# Altium schematic sheet Accessories.SchDoc(Accessories)
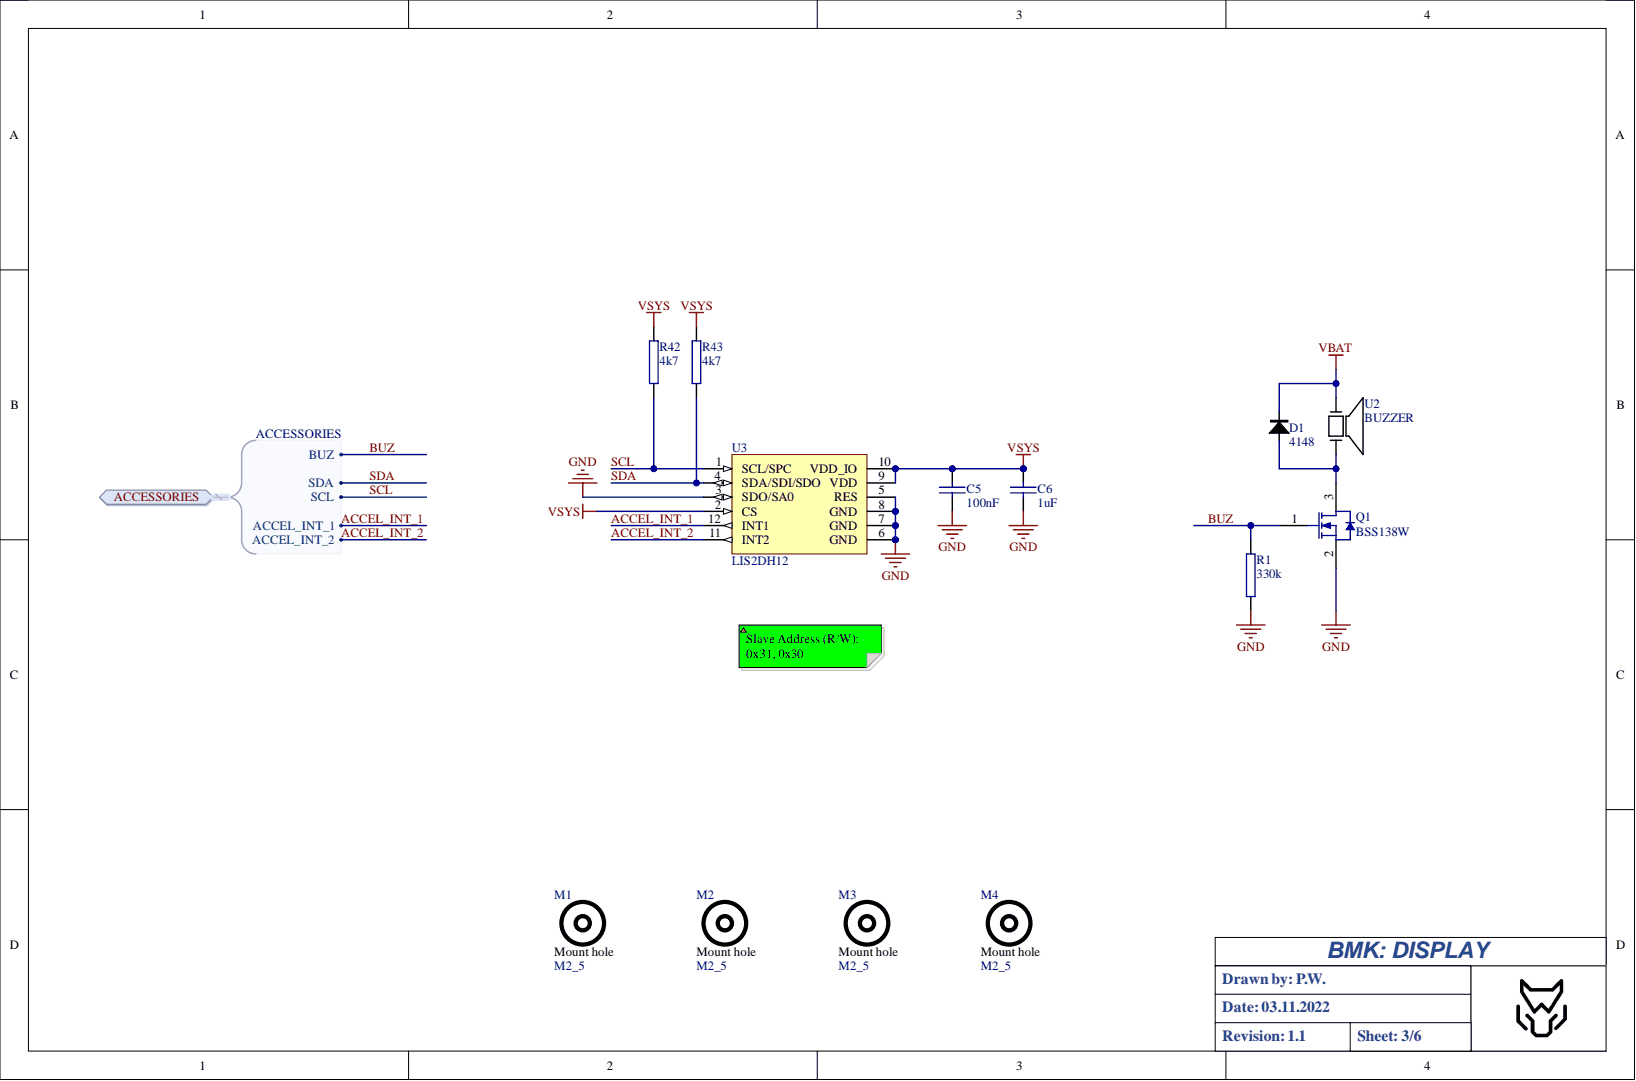
[diagram p0: Accessories.SchDoc]

## Parts
C5  100nF  [C0603L]
C6  1uF  [C0603L]
D1  4148  [0603-DIODE]
M1  M2_5  [M2_5]
M2  M2_5  [M2_5]
M3  M2_5  [M2_5]
M4  M2_5  [M2_5]
Q1  BSS138W  [SOT-323]
R1  330k  [1608[0603]]
R42  4k7  [1608[0603]]
R43  4k7  [1608[0603]]
U2  BUZZER  [SMT28]
U3  LIS2DH12  [LGA50P200X200X100-12N]

## Ports
ACCESSORIES, ACCESSORIES.ACCEL_INT_1, ACCESSORIES.ACCEL_INT_2, ACCESSORIES.BUZ, ACCESSORIES.SCL, ACCESSORIES.SDA

## Nets
GND: C5.2 C6.2 Q1.2 R1.1 U3.3(SDO/SA0) U3.5(RES) U3.6(GND) U3.7(GND) U3.8(GND)
VBAT: D1.2 U2.2
VSYS: C5.1 C6.1 R42.2 R43.2 U3.2(CS) U3.9(VDD) U3.10(VDD_IO)
ACCEL_INT_1: U3.12(INT1) ; port ACCESSORIES
ACCEL_INT_2: U3.11(INT2) ; port ACCESSORIES
BUZ: Q1.1 R1.2 ; port ACCESSORIES
SCL: R42.1 U3.1(SCL/SPC) ; port ACCESSORIES
SDA: R43.1 U3.4(SDA/SDI/SDO) ; port ACCESSORIES
NetD1_1: D1.1 Q1.3 U2.1

## Library data of the parts
C5 C6 = CAP: Capacitor; Component Kind: Standard; Library Name: LIB_PW.IntLib
D1 = D: DIODE; Component Kind: Standard; Library Name: LIB_PW.IntLib
Q1 = BSS138W: N-MOSFET; Component Kind: Standard; Library Name: LIB_PW.IntLib; Manufacturer 1: -; Manufacturer 1 PartNo: -; Supplier 1: -; Supplier 1 PartNo: -
R1 R42 R43 = RES: Component Kind: Standard; Library Name: LIB_PW.IntLib
U3 = (no symbol name): 3-axis - ±2g/±4g/±8g/±16g digital output femto accelerometer; 2nd source part number: 243-3081; Manufacturer: STMicroelectronics; Part number IPS: 00000007817; Artikkelnr Noca: N.A.; Note: MEMS digital output motion sensor ultra low-power high performance 3-axes "femto" accelerometer; 2nd source Supplier: Farnell; Artikkelnr Simpro: N.A.; Component Kind: Standard; Datasheet: http://www.st.com/st-web-ui/static/active/en/resource/technical/document/datasheet/DM00091513.pdf; Footprint Doc: LGA-12; LatestRevisionDate: 2015-09-29; Library Name: LIB_PW.IntLib; Manufacturer Part Number: LIS2DH12; Part Number: LIS2DH12; Pin Count: 12; Published: 2015-09-29; Supplier: Digi-Key; Supplier part number: 497-14851-1-ND; Value: LIS2DH12; Publisher: Nordic Semiconductor
M1-M4 = M2_5: Component Kind: Standard; Library Name: LIB_PW.IntLib
U2 = BUZZER: Component Kind: Standard; Library Name: LIB_PW.IntLib
